# Altium schematic sheet IMX7S_PMIC.SchDoc
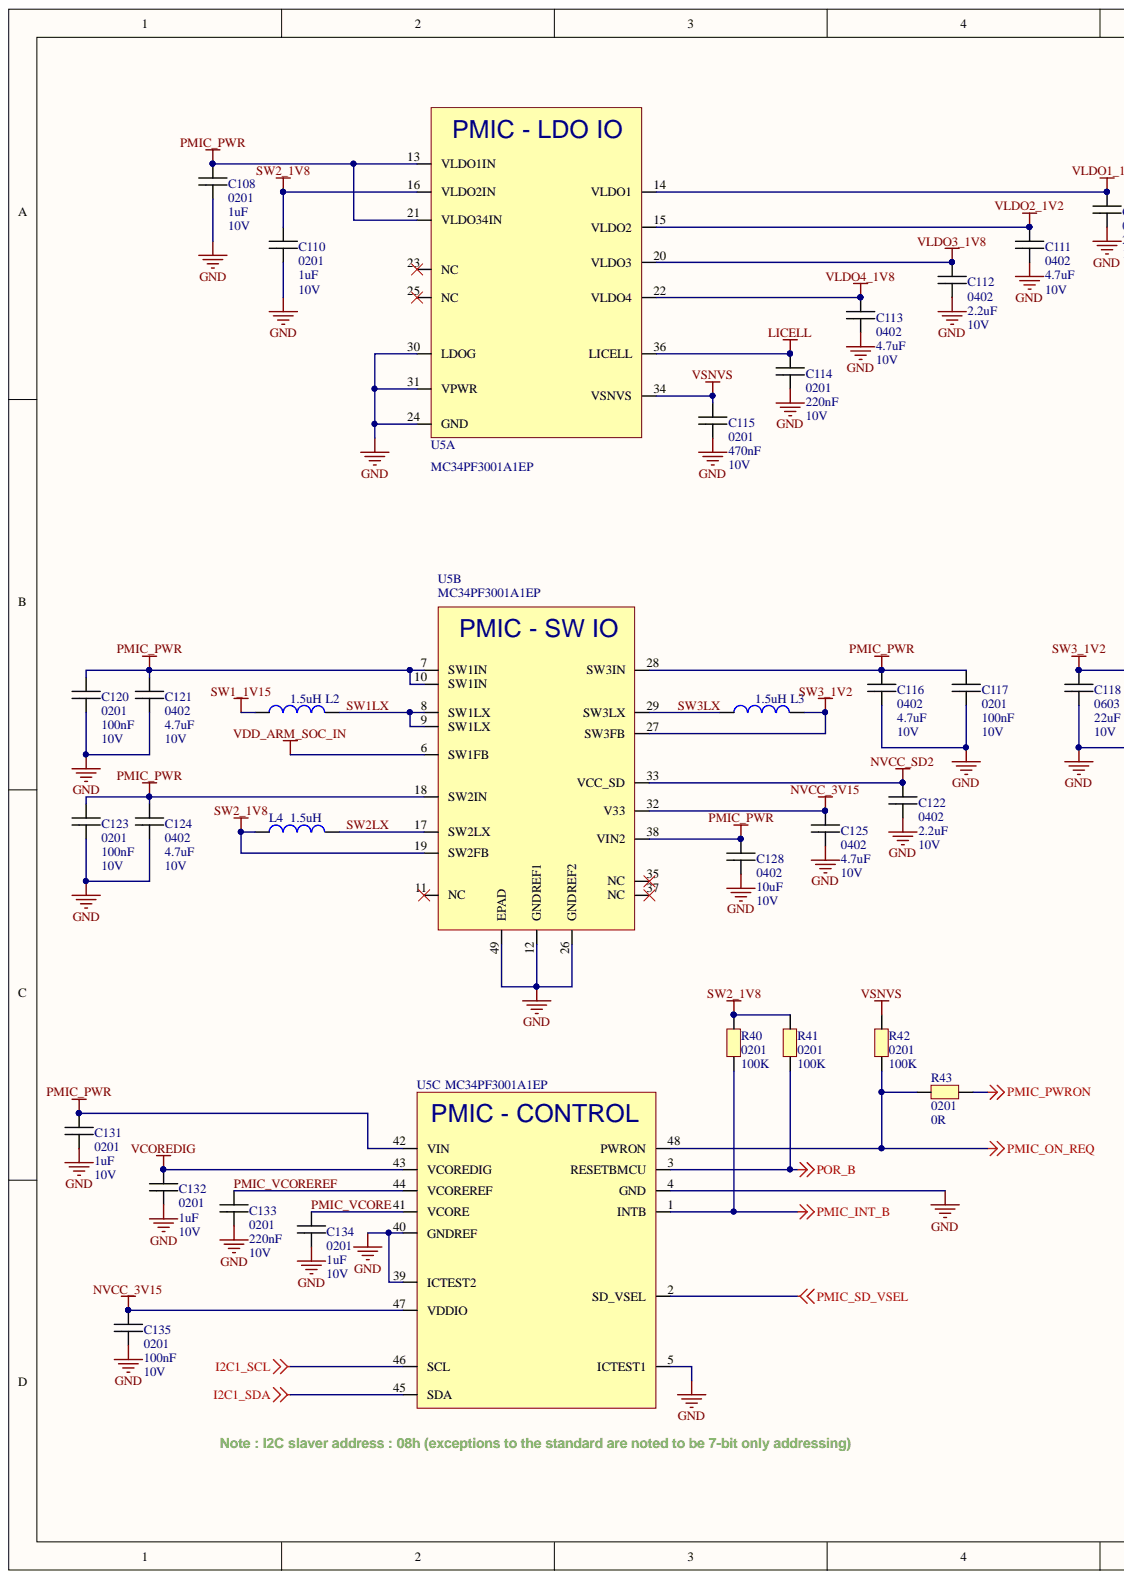
[diagram p0: IMX7S_PMIC.SchDoc, left]
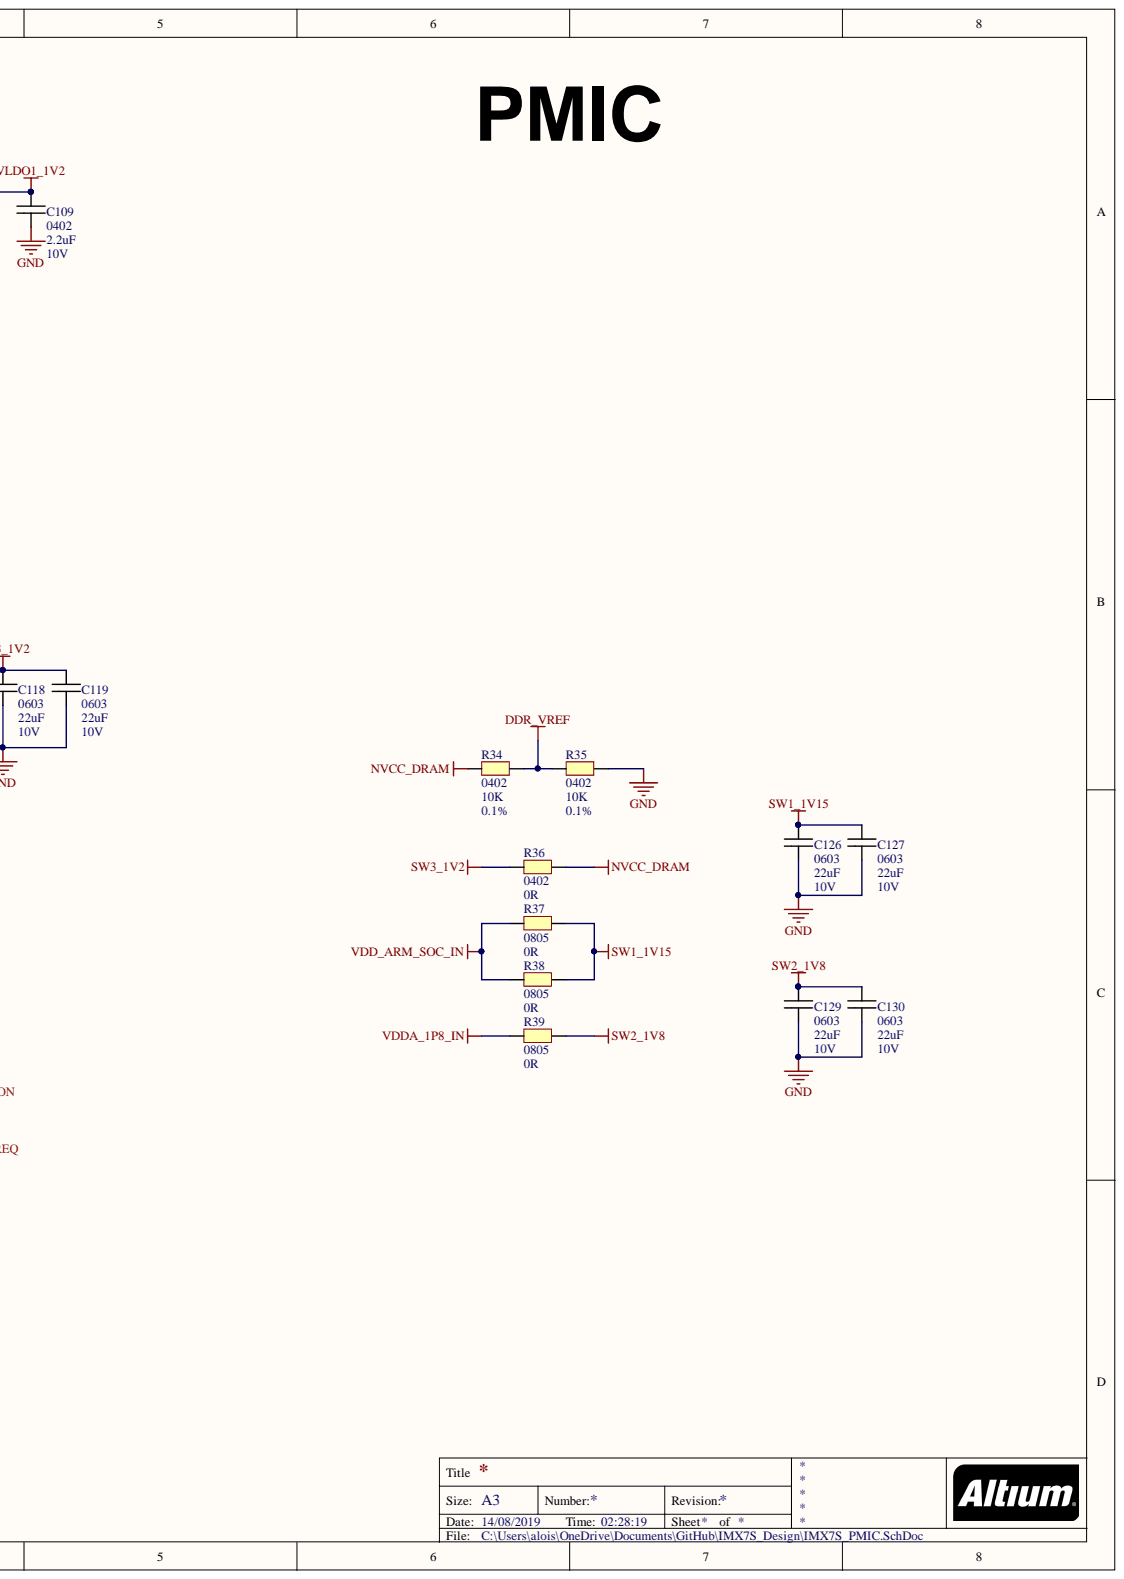
[diagram p1: IMX7S_PMIC.SchDoc, right]

## Parts
C108  value 1uF  PACKAGE: 0201  VOLTAGE: 10V  [C0201]  (hidden comment: C0201)
C109  value 2.2uF  PACKAGE: 0402  VOLTAGE: 10V  [C0402]  (hidden comment: C0402)
C110  value 1uF  PACKAGE: 0201  VOLTAGE: 10V  [C0201]  (hidden comment: C0201)
C111  value 4.7uF  PACKAGE: 0402  VOLTAGE: 10V  [C0402]  (hidden comment: C0402)
C112  value 2.2uF  PACKAGE: 0402  VOLTAGE: 10V  [C0402]  (hidden comment: C0402)
C113  value 4.7uF  PACKAGE: 0402  VOLTAGE: 10V  [C0402]  (hidden comment: C0402)
C114  value 220nF  PACKAGE: 0201  VOLTAGE: 10V  [C0201]  (hidden comment: C0201)
C115  value 470nF  PACKAGE: 0201  VOLTAGE: 10V  [C0201]  (hidden comment: C0201)
C116  value 4.7uF  PACKAGE: 0402  VOLTAGE: 10V  [C0402]  (hidden comment: C0402)
C117  value 100nF  PACKAGE: 0201  VOLTAGE: 10V  [C0201]  (hidden comment: C0201)
C118  value 22uF  PACKAGE: 0603  VOLTAGE: 10V  [C0603]  (hidden comment: C0603)
C119  value 22uF  PACKAGE: 0603  VOLTAGE: 10V  [C0603]  (hidden comment: C0603)
C120  value 100nF  PACKAGE: 0201  VOLTAGE: 10V  [C0201]  (hidden comment: C0201)
C121  value 4.7uF  PACKAGE: 0402  VOLTAGE: 10V  [C0402]  (hidden comment: C0402)
C122  value 2.2uF  PACKAGE: 0402  VOLTAGE: 10V  [C0402]  (hidden comment: C0402)
C123  value 100nF  PACKAGE: 0201  VOLTAGE: 10V  [C0201]  (hidden comment: C0201)
C124  value 4.7uF  PACKAGE: 0402  VOLTAGE: 10V  [C0402]  (hidden comment: C0402)
C125  value 4.7uF  PACKAGE: 0402  VOLTAGE: 10V  [C0402]  (hidden comment: C0402)
C126  value 22uF  PACKAGE: 0603  VOLTAGE: 10V  [C0603]  (hidden comment: C0603)
C127  value 22uF  PACKAGE: 0603  VOLTAGE: 10V  [C0603]  (hidden comment: C0603)
C128  value 10uF  PACKAGE: 0402  VOLTAGE: 10V  [C0402]  (hidden comment: C0402)
C129  value 22uF  PACKAGE: 0603  VOLTAGE: 10V  [C0603]  (hidden comment: C0603)
C130  value 22uF  PACKAGE: 0603  VOLTAGE: 10V  [C0603]  (hidden comment: C0603)
C131  value 1uF  PACKAGE: 0201  VOLTAGE: 10V  [C0201]  (hidden comment: C0201)
C132  value 1uF  PACKAGE: 0201  VOLTAGE: 10V  [C0201]  (hidden comment: C0201)
C133  value 220nF  PACKAGE: 0201  VOLTAGE: 10V  [C0201]  (hidden comment: C0201)
C134  value 1uF  PACKAGE: 0201  VOLTAGE: 10V  [C0201]  (hidden comment: C0201)
C135  value 100nF  PACKAGE: 0201  VOLTAGE: 10V  [C0201]  (hidden comment: C0201)
L2  value 1.5uH  [SELF]  (hidden comment: Self)
L3  value 1.5uH  [SELF]  (hidden comment: Self)
L4  value 1.5uH  [SELF]  (hidden comment: Self)
R34  value 10K  PACKAGE: 0402  VOLTAGE: 0.1%  [R0402]  (hidden comment: R0402)
R35  value 10K  PACKAGE: 0402  VOLTAGE: 0.1%  [R0402]  (hidden comment: R0402)
R36  R0402  PACKAGE: 0402  [R0402]
R37  R0805  value 0R  PACKAGE: 0805  [R0805]
R38  R0805  value 0R  PACKAGE: 0805  [R0805]
R39  R0805  value 0R  PACKAGE: 0805  [R0805]
R40  value 100K  PACKAGE: 0201  [R0201]  (hidden comment: R0201)
R41  value 100K  PACKAGE: 0201  [R0201]  (hidden comment: R0201)
R42  value 100K  PACKAGE: 0201  [R0201]  (hidden comment: R0201)
R43  R0201  value 0R  PACKAGE: 0201  [R0201]
U5  MC34PF3001A1EP  [QFN48]  (parts A,B,C)

## Nets
DDR_VREF: R34.1 R35.2
GND: C108.1 C109.1 C110.1 C111.1 C112.1 C113.1 C114.1 C115.1 C116.1 C117.1 C118.1 C119.1 C120.1 C121.1 C122.1 C123.1 C124.1 C125.1 C126.1 C127.1 C128.1 C129.1 C130.1 C131.1 C132.1 C133.1 C134.1 C135.1 R35.1 U5.4(GND) U5.5(ICTEST1) U5.12(GNDREF1) U5.24(GND) U5.26(GNDREF2) U5.30(LDOG) U5.31(VPWR) U5.39(ICTEST2) U5.40(GNDREF) U5.49(EPAD)
I2C1_SCL: U5.46(SCL)
I2C1_SDA: U5.45(SDA)
LICELL: C114.2 U5.36(LICELL)
NVCC_3V15: C125.2 C135.2 U5.32(V33) U5.47(VDDIO)
NVCC_DRAM: R34.2 R36.2
NVCC_SD2: C122.2 U5.33(VCC_SD)
PMIC_INT_B: R40.1 U5.1(INTB)
PMIC_ON_REQ: R42.1 R43.2 U5.48(PWRON)
PMIC_PWR: C108.2 C116.2 C117.2 C120.2 C121.2 C123.2 C124.2 C128.2 C131.2 U5.7(SW1IN) U5.10(SW1IN) U5.13(VLDO1IN) U5.18(SW2IN) U5.21(VLDO34IN) U5.28(SW3IN) U5.38(VIN2) U5.42(VIN)
PMIC_PWRON: R43.1
PMIC_SD_VSEL: U5.2(SD_VSEL)
POR_B: R41.1 U5.3(RESETBMCU)
SW1_1V15: C126.2 C127.2 L2.1 R37.2 R38.2
SW2_1V8: C110.2 C129.2 C130.2 L4.1 R39.2 R40.2 R41.2 U5.16(VLDO2IN) U5.19(SW2FB)
SW3_1V2: C118.2 C119.2 L3.2 R36.1 U5.27(SW3FB)
VCOREDIG: C132.2 U5.43(VCOREDIG)
VDD_ARM_SOC_IN: R37.1 R38.1 U5.6(SW1FB)
VDDA_1P8_IN: R39.1
VLDO1_1V2: C109.2 U5.14(VLDO1)
VLDO2_1V2: C111.2 U5.15(VLDO2)
VLDO3_1V8: C112.2 U5.20(VLDO3)
VLDO4_1V8: C113.2 U5.22(VLDO4)
VSNVS: C115.2 R42.2 U5.34(VSNVS)
PMIC_VCORE: C134.2 U5.41(VCORE)
PMIC_VCOREREF: C133.2 U5.44(VCOREREF)
SW1LX: L2.2 U5.8(SW1LX) U5.9(SW1LX)
SW2LX: L4.2 U5.17(SW2LX)
SW3LX: L3.1 U5.29(SW3LX)

Not connected: U5 11(NC) 23(NC) 25(NC) 35(NC) 37(NC)

## Library data of the parts
R36 = R0402: VALUE: 0R; VOLTAGE: 0V; Component Kind: Standard; Library Name: Schlib_IMX7.SchLib
R37-R39 = R0805: VOLTAGE: 0V; Component Kind: Standard; Library Name: Schlib_IMX7.SchLib
R40-R43 = R0201: VOLTAGE: 0V; Component Kind: Standard; Library Name: Schlib_IMX7.SchLib
C108 C110 C114 C115 C117 C120 C123 C131-C135 = C0201: Component Kind: Standard; Library Name: Schlib_IMX7.SchLib
C109 C111-C113 C116 C121 C122 C124 C125 C128 = C0402: Component Kind: Standard; Library Name: Schlib_IMX7.SchLib
C118 C119 C126 C127 C129 C130 = C0603: Component Kind: Standard; Library Name: Schlib_IMX7.SchLib
L2-L4 = Self: Component Kind: Standard; Library Name: Schlib_IMX7.SchLib
R34 R35 = R0402: Component Kind: Standard; Library Name: Schlib_IMX7.SchLib; Supplier 1: Farnell; Supplier Part Number 1: 2991897
U5 = MC34PF3001A1EP: Component Kind: Standard; Library Name: Schlib_IMX7.SchLib; Supplier 1: Mouser; Supplier Part Number 1: 841-MC34PF3001A1EP
